# Altium schematic sheet 06-5V_To_3V3.SchDoc
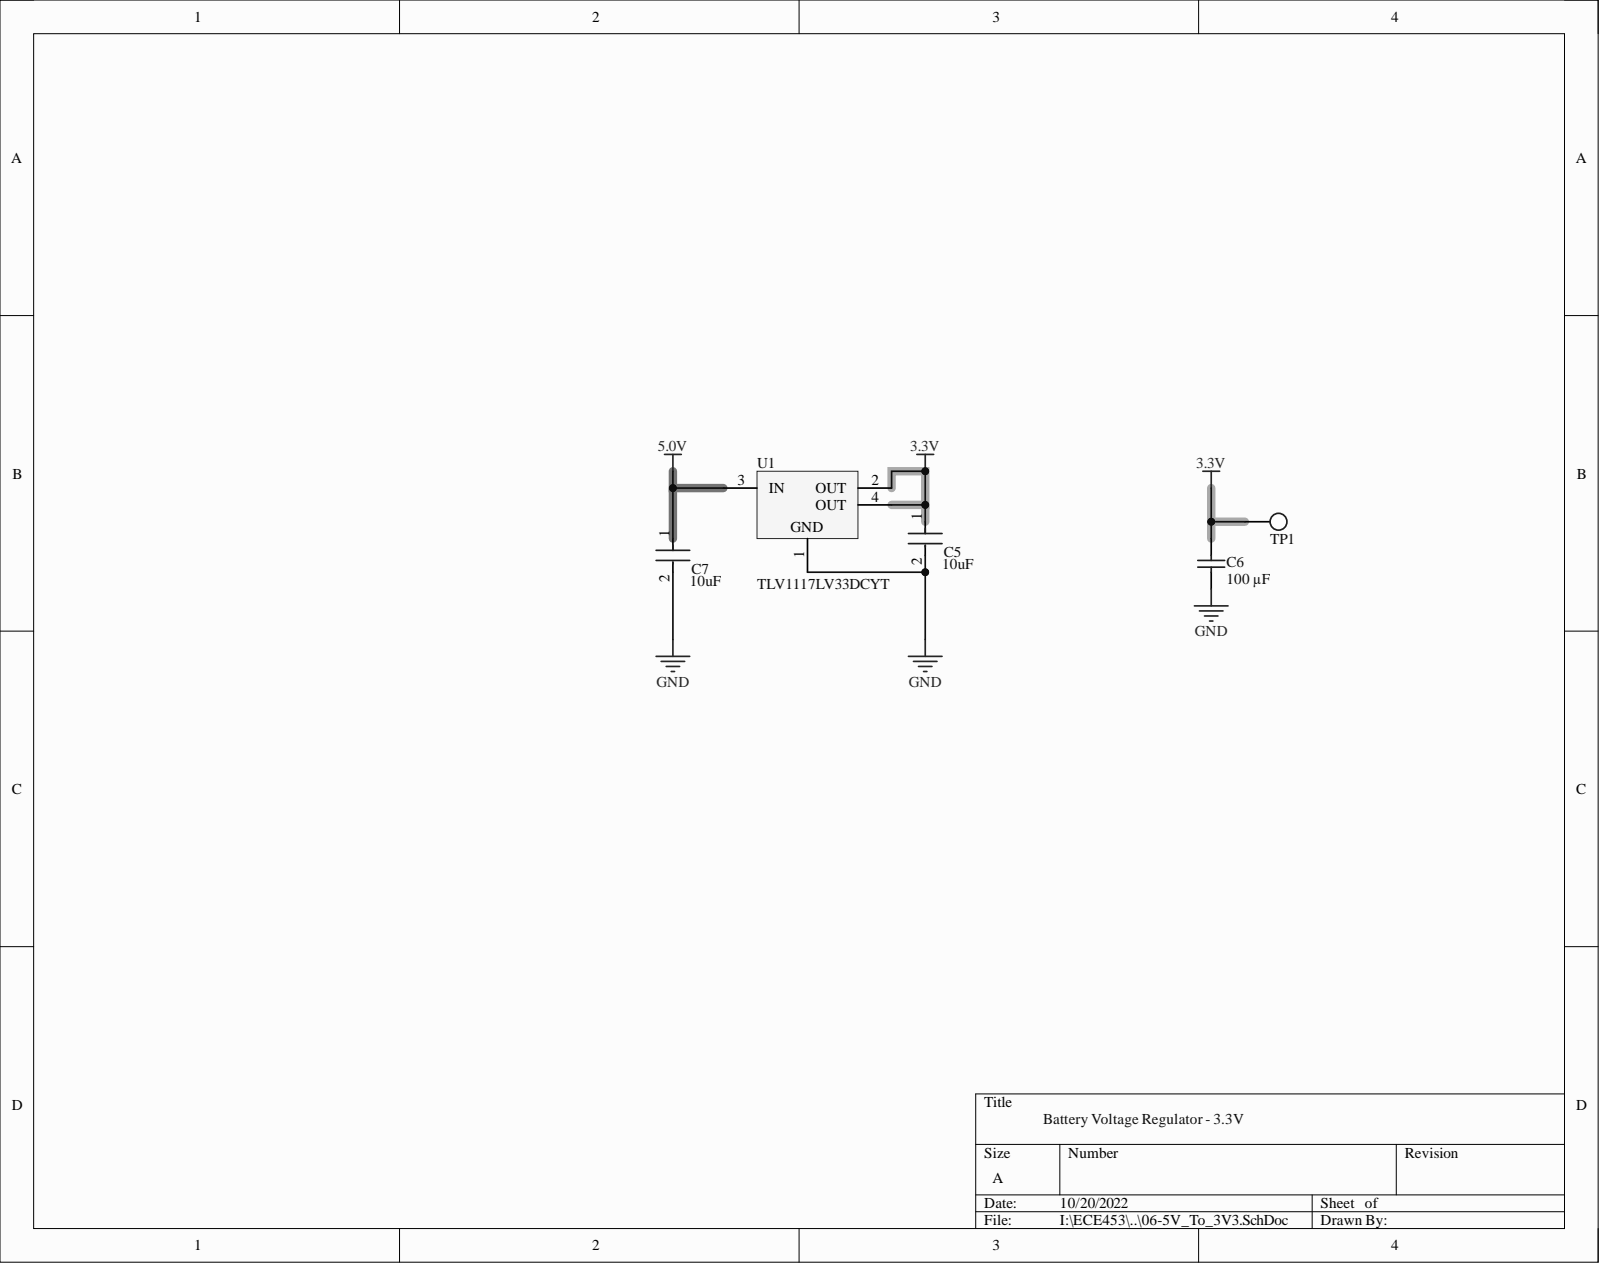
[diagram p0: 06-5V_To_3V3.SchDoc]

## Parts
C5  Capacitance: 10uF  [FP-CL21-IPC_B]  (hidden comment: CL21A106KQCLNNC)
C6  EEEHA1A101WP  [CAP_EEEHA1A101WP]  (value not drawn)
C7  Capacitance: 10uF  [FP-CL21-IPC_B]  (hidden comment: CL21A106KQCLNNC)
TP1  TP_1206  [TP-SMC-1206]  (value not drawn)
U1  TLV1117LV33DCYT  [DCY0004A_M]

## Nets
3.3V: C5.1 C6.2 TP1.1 U1.2(OUT) U1.4(OUT)
5.0V: C7.1 U1.3(IN)
GND: C5.2 C6.1 C7.2 U1.1

## Library data of the parts
C5 C7 = CMP-13271-003047-1: CL21 Series 0805 10uF 6.3V ±10% Tolerance X5R Multilayer Ceramic Chip Capacitor; Case Code (Imperial): 0805; Case Code (Metric): 2012; Case/Package: 0805; Component Kind: Standard; Depth: 1.25mm; Dielectric: X5R; Height: 0.85mm; Lead Free: Lead Free; Length: 2mm; Library Name: Altium Content Vault; Max Operating Temperature: 85°C; Min Operating Temperature: -55°C; Mount: Surface Mount; Package Quantity: 1; Packaging: Tape and Reel; Radiation Hardening: No; Thickness: 0.037inch; Tolerance: 10%; Voltage Rating: 6.3V; Width: 0.049inch
C6 = EEEHA1A101WP: 100ÂµF 10V Aluminum Electrolytic Capacitors Radial, Can - SMD 1000 Hrs @ 105Â°C; Capacitance: 100 µF; MF: Panasonic; MP: EEE-HA1A101WP; Availability: In Stock; Check_prices: https://www.snapeda.com/parts/EEE-HA1A101WP/Panasonic/view-part/?ref=eda; Component Kind: Standard; Library Name: team6.SCHLIB; Package: RADIAL-2 Panasonic; Price: None; Purchase-URL: https://pricing.snapeda.com/search/part/EEE-HA1A101WP/?ref=eda; SnapEDA_Link: https://www.snapeda.com/parts/EEE-HA1A101WP/Panasonic/view-part/?ref=snap
TP1 = TP_1206: Test Point SMD 1206; Manufacturer: TE Connectivity (Tyco Electronics); Manufacturer Part Number: 1625854-1; Power Rating: N/R; Resistance Value: 0 Ohm; Case Size: 1206; Component Kind: Standard; ComponentLink1Description: https://datasheet.ciiva.com/6439/eng-ds-1773266-a-6439169.pdf; ComponentLink1URL: https://datasheet.ciiva.com/6439/eng-ds-1773266-a-6439169.pdf; ComponentLink2Description: https://datasheet.ciiva.com/30136/1773266-a-30136341.pdf; ComponentLink2URL: https://datasheet.ciiva.com/30136/1773266-a-30136341.pdf; ComponentLink3Description: https://datasheet.ciiva.com/6439/eng-ds-1773266-a-6439080.pdf; ComponentLink3URL: https://datasheet.ciiva.com/6439/eng-ds-1773266-a-6439080.pdf; ComponentLink4Description: https://datasheet.ciiva.com/6439/eng-ds-1773266-a-6439081.pdf; ComponentLink4URL: https://datasheet.ciiva.com/6439/eng-ds-1773266-a-6439081.pdf; ComponentLink5Description: https://datasheet.ciiva.com/6439/eng-ds-1773266-a-6439083.pdf; ComponentLink5URL: https://datasheet.ciiva.com/6439/eng-ds-1773266-a-6439083.pdf; Dimension: 3.2 x 1.6 x 1.25mm; Family: RC; Library Name: ECE453-F22-Power.SCHLIB; Mounting: Surface Mount; Operating Temperature: -55 to 125 C; Packaging: Tape and Reel; Part No: 1625854-1; Pricing 1: 1=0.28, 10=0.261, 25=0.224, 50=0.1902, 100=0.1828, 250=0.1641, 500=0.1567, 1000=0.1306 (USD); RoHS: Yes; Stock 1: 31937; Supplier: Digi-Key; Supplier Part Number: A106143CT-ND; Temperature Coefficient: N/R; Terminal Pitch: N/R; Termination Style: N/R; Tolerance: N/R
U1 = CMP-0395-00499-2: Single Output LDO, 1 A, Fixed 3.3 V Output, 2 to 5.5 V Input, 4-pin SOT-223 (DCY), -40 to 125 degC, Green (RoHS & no Sb/Br); Manufacturer: Texas Instruments; Accuracy(%): 2; Fixed Output Options(V): 3.3; Iout(Max)(A): 1; Iq(Typ)(mA): 0.05; SubFamily: Single Channel LDO; Vdo(Typ)(mV): 1200; Vin(Max)(V): 5.5; Code_JEDEC: TO-261; Component Kind: Standard; ComponentLink1Description: Manufacturer URL; ComponentLink1URL: http://www.ti.com/; ComponentLink2Description: Datasheet; ComponentLink2URL: http://www.ti.com/general/docs/lit/getliterature.tsp?genericPartNumber=TLV1117LV33&fileType=pdf; ComponentLink3Description: Package Specification; ComponentLink3URL: http://www.ti.com/litv/pdf/mpds094a; DatasheetVersion: SBVS160A; Eco-Plan: Green (RoHS & no Sb/Br); Library Name: Altium Content Vault; Mounting Technology: Surface Mount; PackageDescription: 4-Pin Plastic Small Outline, Body 3.5 x 6.5 mm, Pitch 2.3 mm; PackageReference: DCY0004A; PackageVersion: 4202506/B; PartNumber: TLV1117LV33DCYT; Vin(Min)(V): 2; Vout Adj(Max)(V): 3.3; Vout Adj(Min)(V): 3.3
